# Altium schematic sheet LOGIC_POWER.SchDoc(LOGIC_POWER)
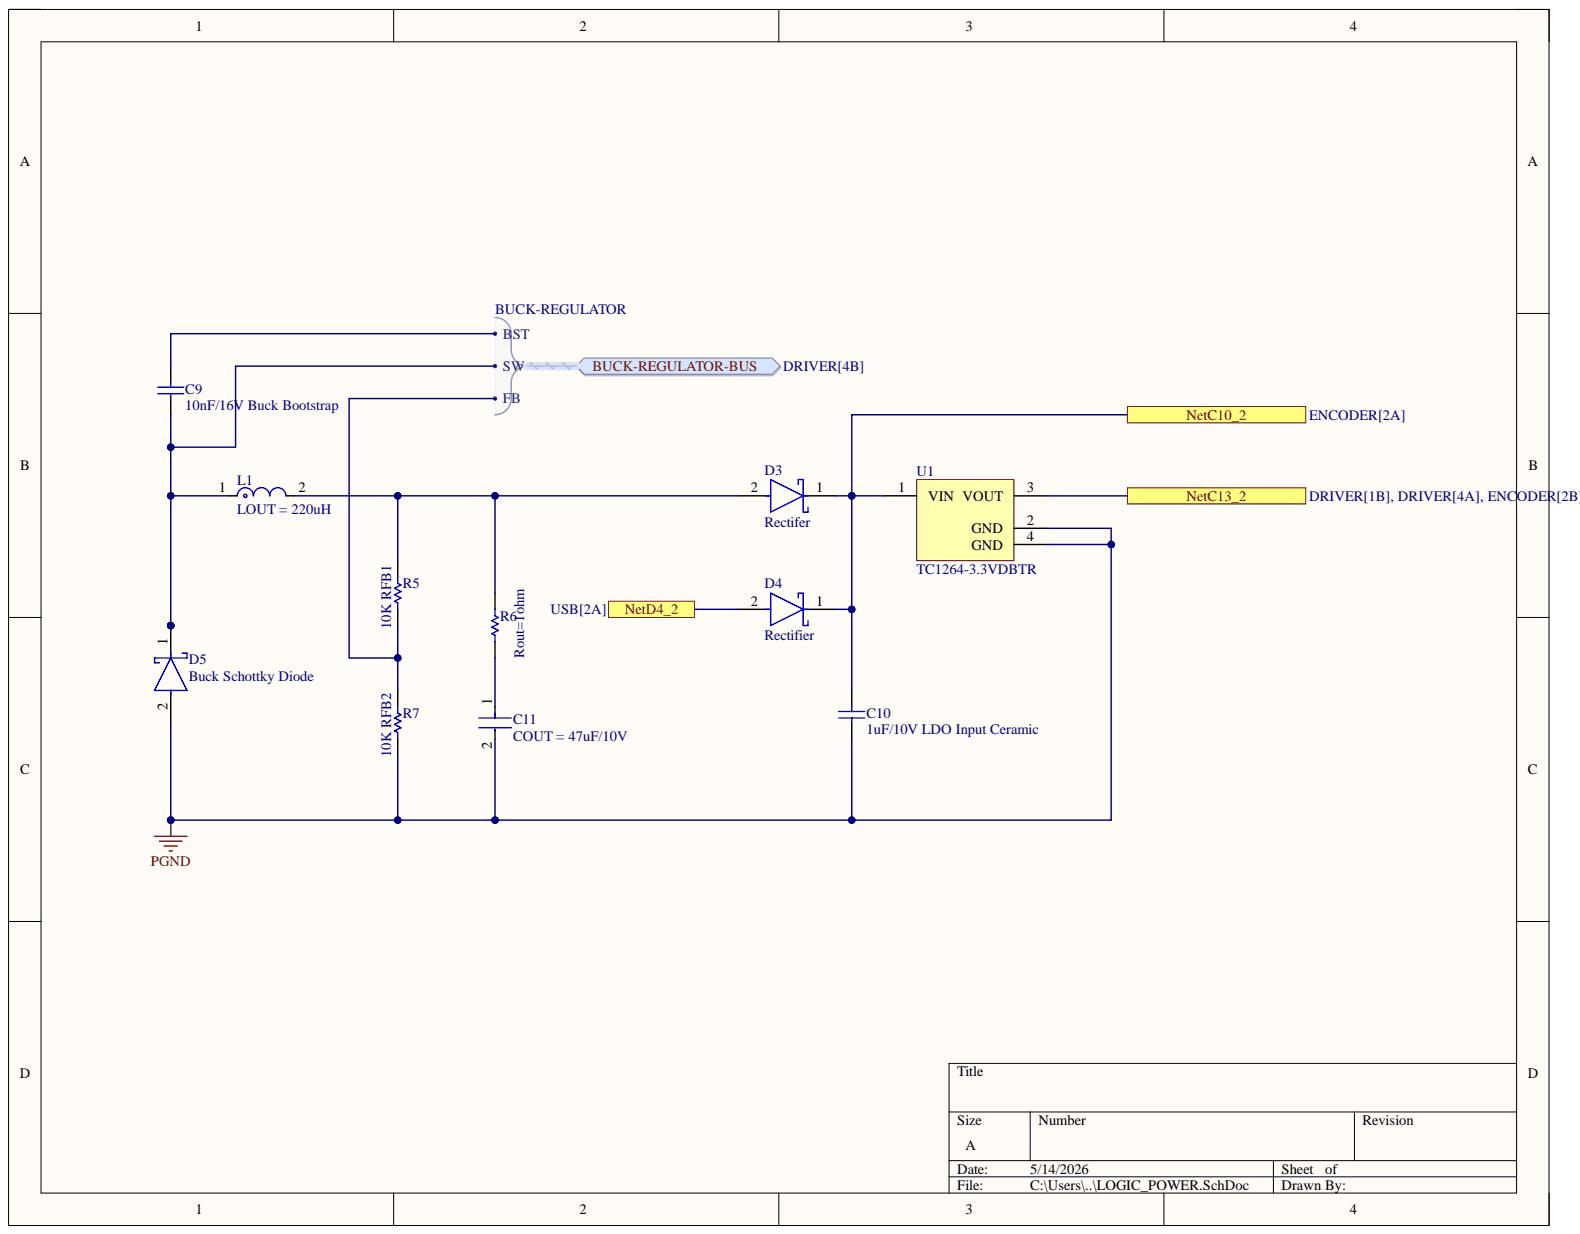
[diagram p0: LOGIC_POWER.SchDoc]

## Parts
C9  10nF/16V Buck Bootstrap  [CAPC1608X87X35LL15T15]
C10  1uF/10V LDO Input Ceramic  [CAPC1206(3216)130_N]
C11  COUT = 47uF/10V  [FP-GRM32E-0_3-0_2-IPC_B]
D3  Rectifer  [FP-477AD-MFG]
D4  Rectifier  [FP-477AD-MFG]
D5  Buck Schottky Diode  [FP-DO-214AB-MFG]
L1  LOUT = 220uH  Inductance: 220uH  [FP-DS126C2-MFG]
R5  10K RFB1  [RESC0805(2012)_L]
R6  Rout=1ohm  [RESC1609X50X30LL10T20]
R7  10K RFB2  [RESC0805(2012)_L]
U1  TC1264-3.3VDBTR  [SOT-223-DB3_N]

## Ports
3.3V-LOGIC-SUPPLY, 5V- SUPPLY, BUCK-REGULATOR-BUS, BUCK-REGULATOR-BUS.BST, BUCK-REGULATOR-BUS.FB, BUCK-REGULATOR-BUS.SW, USB_5V

## Nets
GND: C10.1 C11.2 D5.2 R7.2 U1.2(GND) U1.4(GND)
3.3V-LOGIC-SUPPLY: U1.3(VOUT) ; port 3.3V-LOGIC-SUPPLY
NetC9_1: C9.1 D5.1 L1.1 ; port BUCK-REGULATOR-BUS
NetC9_2: C9.2 ; port BUCK-REGULATOR-BUS
NetC10_2: C10.2 D3.1 D4.1 U1.1(VIN) ; port 5V- SUPPLY
NetC11_1: C11.1 R6.1
NetD3_2: D3.2 L1.2 R5.1 R6.2
NetD4_2: D4.2 ; port USB_5V
NetR5_2: R5.2 R7.1 ; port BUCK-REGULATOR-BUS

## Library data of the parts
C9 = CMP-2006-03842-1: Manufacturer: Kemet; Part Number: C0603S103K4RACTU; Component Kind: Standard; Library Name: Altium Content Vault
C10 = CMP-1037-04671-1: CAP 1uF 10V ±5% 1206 (3216 Metric) Thickness 1.3mm SMD; Case-EIA: 1206; Case-Metric: 3216; Tolerance: Â±5%; Component Kind: Standard; Library Name: Altium Content Vault; Max Thickness: 1.3 mm; Rated Voltage: 10 V; Technology: SMT; Value: 1uF
C11 = CMP-06035-037560-1: Chip Multilayer Ceramic Capacitors for General Purpose, 1210, 47uF, X6S, 22%, 20%, 10V; Dissipation Factor: 0.035%; Capacitance: 47uF; Case Code (Imperial): 1210; Case Code (Metric): 3225; Case/Package: 1210; Component Kind: Standard; Depth: 2.5mm; Dielectric: X6S; Dielectric Material: Ceramic; Height: 2.5mm; Length: 3.2mm; Library Name: Altium Content Vault; Max Operating Temperature: 105°C; Min Operating Temperature: -55°C; Mount: Surface Mount; Package Quantity: 1000; Packaging: Tape and Reel; Radiation Hardening: No; Termination: SMD/SMT; Thickness: 0.106inch; Tolerance: 20%; Voltage: 10V; Voltage Rating: 10V; Voltage Rating (DC): 10V; Width: 2.5mm
D3 D4 = CMP-00278-93203856-2: NRVBSS14HE Rectifier Diode, Schottky, 1 Phase, 1 Element, 1A, 40V V(RRM), Silicon; Manufacturer Lifecycle Status: ACTIVE; Capacitance: 55pF; Max Forward Surge Current (Ifsm): 25A; Max Junction Temperature (Tj): 150°C; Peak Reverse Current: 5µA; Component Kind: Standard; Forward Current: 1A; Height: 750µm; Library Name: Altium Content Vault; Lifecycle Status: Production; Max Operating Temperature: 150°C; Max Repetitive Reverse Voltage (Vrrm): 40V; Min Operating Temperature: -55°C; NexarPartId: 93203856; Reverse Voltage: 40V; RoHS: Compliant
D5 = CMP-00252-99180441-1: HSM560JE3/TR13 Schottky 5.0A, 60V, Vf=0.65V Smcj (Do-214Ab) Rohs Compliant: Yes; Average Rectified Current: 5A; Capacitance: 355pF; Element Configuration: Single; Forward Voltage: 650mV; Max Forward Surge Current (Ifsm): 250A; Max Junction Temperature (Tj): 175°C; Peak Reverse Current: 250µA; Component Kind: Standard; Forward Current: 5A; Height: 2.41mm; Library Name: Altium Content Vault; Lifecycle Status: Production; Max Operating Temperature: 175°C; Max Repetitive Reverse Voltage (Vrrm): 60V; Max Reverse Voltage (DC): 60V; Min Operating Temperature: -55°C; Mount: Surface Mount; NexarPartId: 99180441; Number of Pins: 2; Packaging: Tape and Reel; Peak Non-Repetitive Surge Current: 250A; Radiation Hardening: No; Reverse Voltage: 60V; RoHS: Compliant; Schedule B: 8541100050
L1 = CMP-06042-003400-1: Shielded Wirewound Inductor 220uH 20% 1000mA 0.35Ω SMD; Core Material: Ferrite; Current Rating: 1A; DC Resistance (DCR): 350mR; Test Frequency: 100kHz; Case/Package: Nonstandard; Component Kind: Standard; Height - Seated (Max): 0.268inch; Length: 0.504inch; Library Name: Altium Content Vault; Max Operating Temperature: 85°C; Min Operating Temperature: -40°C; Mount: Base; Packaging: Tape and Reel; Resistor Type: Wirewound; Saturation Current: 1A; Shielding: Shielded; Tolerance: 20%; Width: 0.504inch
R5 R7 = CMP-1013-00098-1: 10K 0.125W 5% 0805 (2012 Metric)  SMD; Case-EIA: 0805; Case-Metric: 2012; Value: 10000.00 Ohm; Component Kind: Standard; Library Name: Altium Content Vault; Power: 0.125 W; Technology: SMT; Tolerance: 5 %
R6 = CMP-2000-03051-1: Manufacturer: Vishay Dale; Part Number: CRCW06031R00JNTA; Component Kind: Standard; Library Name: Altium Content Vault
U1 = CMP-0136-00429-1: 800 mA Fixed-Output CMOS LDO with Shutdown, 3-Pin SOT-223, Extended Temperature, Tape and Reel; Component Kind: Standard; DatasheetVersion: revC, Oct-2006; Library Name: Altium Content Vault; PackageDescription: 3-Lead Plastic Small Outline Transistor (DB) [SOT-223]; PackageReference: SOT-223-DB3; PackageVersion: revBB, Aug-2009
